# Altium schematic sheet Sheet2.SchDoc(Sheet2)
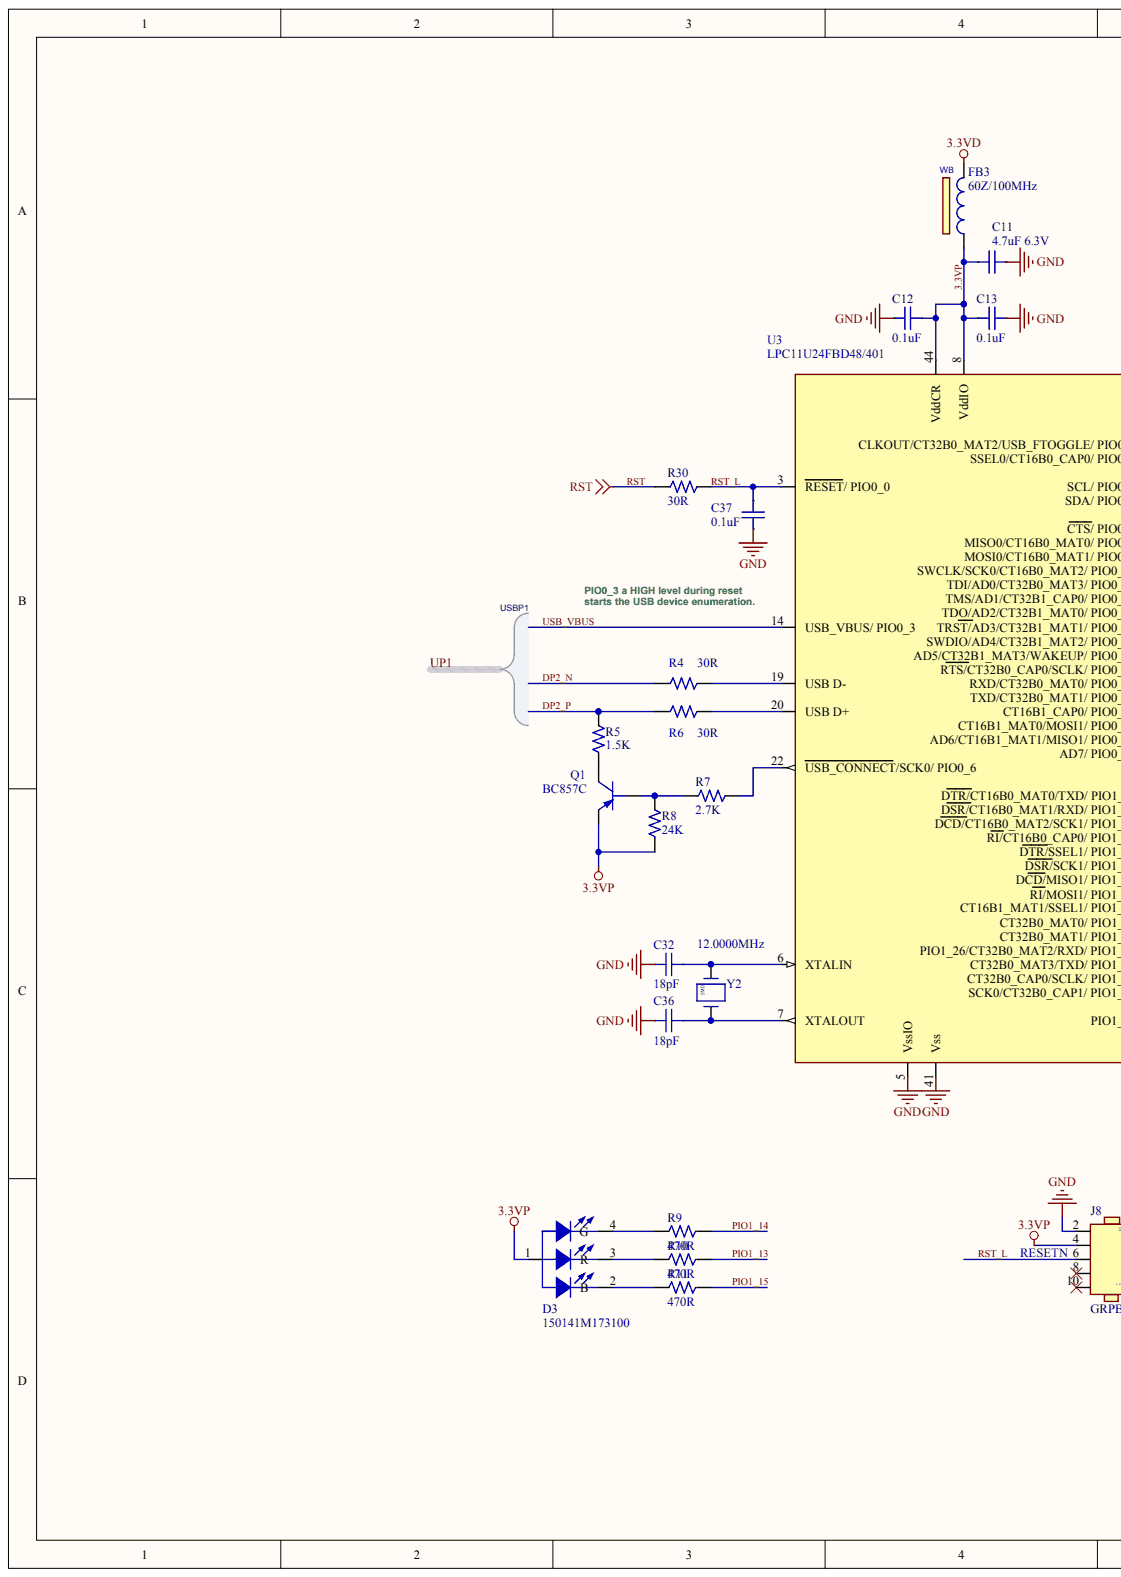
[diagram p0: Sheet2.SchDoc, left]
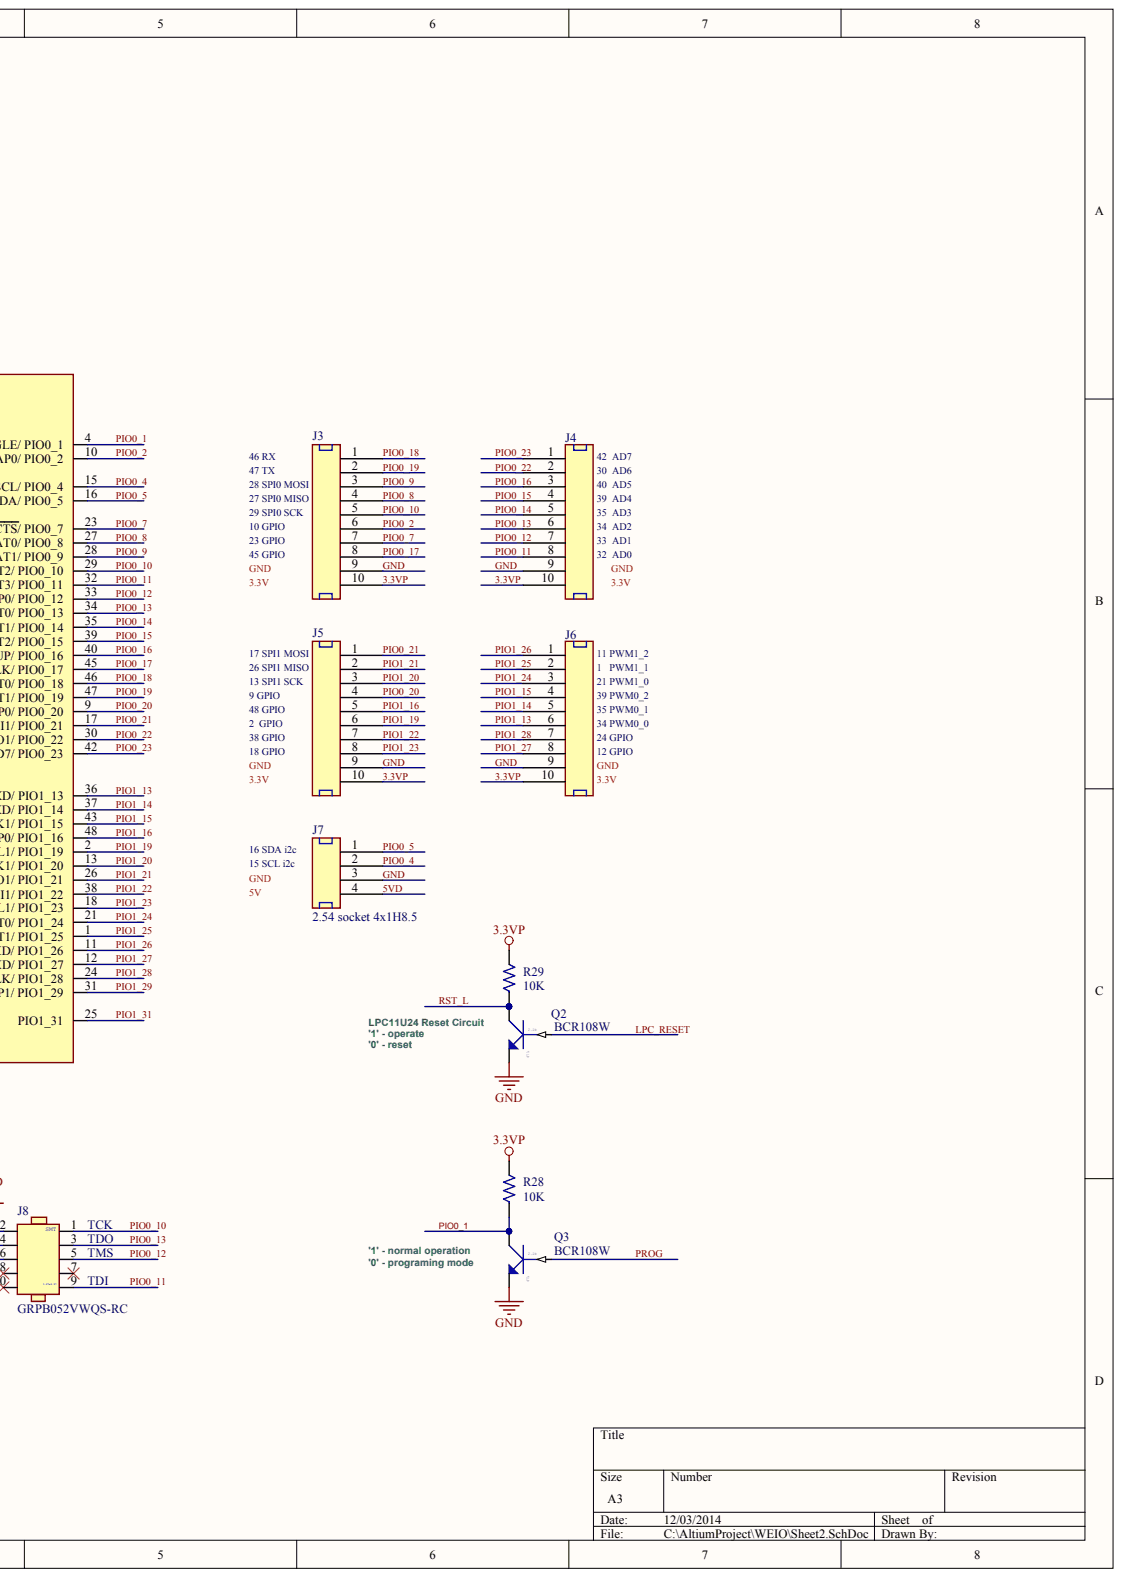
[diagram p1: Sheet2.SchDoc, right]

## Parts
C11  Voltage: 6.3V  (hidden comment: 4.7uF 6.3V)
C12  value 0.1uF  (hidden comment: 100nF)
C13  value 0.1uF  (hidden comment: 100nF)
C32  18pF
C36  18pF
C37  value 0.1uF  (hidden comment: 100nF)
D3  150141M173100
FB3  60Z/100MHz
J3  2.54 socket 10x1H8.5  (value not drawn)
J4  2.54 socket 10x1H8.5  (value not drawn)
J5  2.54 socket 10x1H8.5  (value not drawn)
J6  2.54 socket 10x1H8.5  (value not drawn)
J7  2.54 socket 4x1H8.5
J8  GRPB052VWQS-RC
Q1  BC857C
Q2  BCR108W
Q3  BCR108W
R4  30R
R5  1.5K
R6  30R
R7  2.7K
R8  24K
R9  470R
R10  470R
R11  470R
R28  10K
R29  10K
R30  30R
U3  LPC11U24FBD48/401
Y2  12.0000MHz

## Nets
3.3VD: FB3.2
3.3VP: C11.2 C12.2 C13.1 D3.1 FB3.1 J3.10 J4.10 J5.10 J6.10 J8.4 Q1.2 R8.1 R28.2 R29.2 U3.8(VddIO) U3.44(VddCR)
5VD: J7.4
DP2_N: R4.1
DP2_P: R5.2 R6.1
GND: C11.1 C12.1 C13.2 C32.2 C36.1 C37.2 J3.9 J4.9 J5.9 J6.9 J7.3 J8.2 Q2.2 Q3.2 U3.5(VssIO) U3.41(Vss)
LPC_RESET: Q2.1
PIO0_1: Q3.3 R28.1 U3.4
PIO0_2: J3.6 U3.10
PIO0_4: J7.2 U3.15
PIO0_5: J7.1 U3.16
PIO0_7: J3.7 U3.23
PIO0_8: J3.4 U3.27
PIO0_9: J3.3 U3.28
PIO0_10: J3.5 J8.1(SMT) U3.29
PIO0_11: J4.8 J8.9 U3.32
PIO0_12: J4.7 J8.5 U3.33
PIO0_13: J4.6 J8.3 U3.34
PIO0_14: J4.5 U3.35
PIO0_15: J4.4 U3.39
PIO0_16: J4.3 U3.40
PIO0_17: J3.8 U3.45
PIO0_18: J3.1 U3.46
PIO0_19: J3.2 U3.47
PIO0_20: J5.4 U3.9
PIO0_21: J5.1 U3.17
PIO0_22: J4.2 U3.30
PIO0_23: J4.1 U3.42
PIO1_13: J6.6 R10.1 U3.36(DTR/CT16B0_MAT0/TXD/_PIO1_13)
PIO1_14: J6.5 R9.1 U3.37(DSR/CT16B0_MAT1/RXD/_PIO1_14)
PIO1_15: J6.4 R11.1 U3.43(DCD/CT16B0_MAT2/SCK1/_PIO1_15)
PIO1_16: J5.5 U3.48(RI/CT16B0_CAP0/_PIO1_16)
PIO1_19: J5.6 U3.2(DTR/SSEL1/_PIO1_19)
PIO1_20: J5.3 U3.13(DSR/SCK1/_PIO1_20)
PIO1_21: J5.2 U3.26(DCD/MISO1/_PIO1_21)
PIO1_22: J5.7 U3.38(RI/MOSI1/_PIO1_22)
PIO1_23: J5.8 U3.18(CT16B1_MAT1/SSEL1/_PIO1_23)
PIO1_24: J6.3 U3.21(CT32B0_MAT0/_PIO1_24)
PIO1_25: J6.2 U3.1(CT32B0_MAT1/_PIO1_25)
PIO1_26: J6.1 U3.11(PIO1_26/CT32B0_MAT2/RXD/_PIO1_26)
PIO1_27: J6.8 U3.12(CT32B0_MAT3/TXD/_PIO1_27)
PIO1_28: J6.7 U3.24(CT32B0_CAP0/SCLK/_PIO1_28)
PIO1_29: U3.31(SCK0/CT32B0_CAP1/_PIO1_29)
PIO1_31: U3.25(PIO1_31)
PROG: Q3.1
RST: R30.1
RST_L: C37.1 J8.6 Q2.3 R29.1 R30.2 U3.3(RESET/)
USB_VBUS: U3.14(USB_VBUS/)
NetC32_1: C32.1 U3.6(XTALIN) Y2.1
NetC36_2: C36.2 U3.7(XTALOUT) Y2.3
NetD3_2: D3.2(B) R11.2
NetD3_3: D3.3(R) R10.2
NetD3_4: D3.4(G) R9.2
NetQ1_1: Q1.1 R7.1 R8.2
NetQ1_3: Q1.3 R5.1
NetR4_2: R4.2 U3.19(USB_D-)
NetR6_2: R6.2 U3.20(USB_D+)
NetR7_2: R7.2 U3.22(USB_CONNECT/SCK0/)

Not connected: J8 7 8 10

## Library data of the parts
C11 = (no symbol name): Part Number: 00000560; Manufacturer Part Number: C1608X5R0J475K; Manufacturer: TDK; Tolerance: +-10%; PSpice: C; Value: 4.7uF 6.3V; Part Type: Ceramic\1608; RoHS: Yes; Package: 180mmT&R4000; Availability: In Stock; Datasheet: E:\pdf\KONDENSATORIAI\CER_smd\e412_c.pdf
C12 C13 C37 = (no symbol name): Part Number: 00005500; Manufacturer Part Number: C1005X5R1C104K; Manufacturer: TDK; Voltage: 16V; Tolerance: +-10%; PSpice: C; Part Type: Ceramic\1005; RoHS: Yes; Availability: In Stock; Datasheet: E:\pdf\KONDENSATORIAI\CER_smd\e412_c.pdf
C32 C36 = (no symbol name): Part Number: 00000158; COMPHEIGHT: 0.8; Allegro PCB Footprint: C1608_I; Voltage: 50V; Tolerance: +-5%; PSpice: C; SMD: Yes; Distributor Part Number: 1001201; Distributor: Elgerta; Price: 0.015; Part Type: Ceramic; Availability: In Stock; Value: 18pF
FB3 = (no symbol name): Manufacturer: Coilmaster Electronics Co,,Ltd.; Manufacturer Part Number: MI1608-600; Part Number: 20000001; COMPHEIGHT: 0.8; Allegro PCB Footprint: MI1608_I; Tolerance: 25%; PSpice: L; Lead time: 3-4w; Price: 0.03; SMD: Yes; Part Type: Inductor\Ferrite beads 1608; Availability: 3-4weeks; Main distributor: Coilmaster; MOQ: 4000; ActivepartsID: http://www.coilmaster.com.tw/Web%20Site%20Catalogue%202007/T-MB,MI/MI1608-LF-RoHS.pdf; Data: 2009-05-15; Value: 60Z/100MHz; Datasheet: E:\pdf\induktyvumai\smd\MI1608-600-3A-LF-Teltonika.pdf
J8 = (no symbol name): Part Number: 70200208W; Manufacturer: Sullins Connector Solutions; COMPHEIGHT: 5; Rating: 250V; Allegro PCB Footprint: 127X127_12MH_SMT_H5; Gaminys: 8DEV; Komentaras: $; Part Type: Connector\1.27x1.27; SMD: Yes; Manufacturer Part Number: GRPB052VWQS-RC; Distributor Part Number: S9012E-05-ND; Distributor: Digi-Key; Price: 0.5075; Package: tube; ActivepartsID: http://media.digikey.com/pdf/Data%20Sheets/Sullins%20PDFs/GRPB_%20_2VWQS-RC%2010958-C.pdf; Data: 2013-06-07; Datasheet: E:\PDF\Jungtys\GRPB_ _2VWQS-RC 10958-C.pdf
Q1 = (no symbol name): Manufacturer Part Number: BC857C T/R; Manufacturer: NXP; Part Number: 40010110; COMPHEIGHT: 1.0; Allegro PCB Footprint: SOT23; Rating: 250mW; SMD: Yes; Distributor Part Number: 771-BC857C-T/R; Distributor: Mouser; Price: 0.043; Part Type: Transistors\PNP; Package: 3000T&R; Availability: In Stock; ActivepartsID: http://www.nxp.com/acrobat_download/datasheets/BC856_BC857_BC858_6.pdf; Data: 2008-04-23; Datasheet: E:\pdf\tranzistoriai\BC856_BC857_BC858_6.pdf
Q2 Q3 = (no symbol name): Part Number: 40030012; Manufacturer: INFINEON; COMPHEIGHT: 0.9; Rating: 250mW(<+124deg); Allegro PCB Footprint: SOT323_T,SOT323_TA; Part Type: Transistors; SMD: Yes; Manufacturer Part Number: BCR108W; Availability: In Stock; Data: 2008-11-27; Datasheet: E:\pdf\tranzistoriai\BCR108.PDF
R4 R6 R30 = (no symbol name): Part Number: 10011021W; Manufacturer Part Number: RC0402JR-0730RL; Manufacturer: YAGEO; COMPHEIGHT: 0.35; Power: 1/16W; Tolerance: 5%; Allegro PCB Footprint: R1005_TM2; PSpice: R; Gaminys: EAR2; Komentaras: $; Value: 30R; Part type: SMD\0402; SMD: Yes; Distributor Part Number: 311-30JRTR-ND; Distributor: Digi-Key; Price: 0.00213; Package: 10000T&R; Availability: In Stock; ActivepartsID: http://www.yageo.com/pdf/Pu-RC0402_51_PbFree_L_2.pdf; Data: 17/02/2010; Datasheet: E:\pdf\rezistoriai\Pu-RC0402_51_PbFree_L_2.pdf
R5 = (no symbol name): Part Number: 10011084; Manufacturer Part Number: RC0402JR-1K5L; Manufacturer: YAGEO; Power: 1/16W; Tolerance: 5%; PSpice: R; Value: 1.5K; Part type: SMD\0402; RoHS: Yes; Availability: In Stock; Datasheet: E:\pdf\rezistoriai\PSG_R-chip_Yageo_2005.pdf
R7 = (no symbol name): Part Number: 10011090; Manufacturer Part Number: RC0402JR-072K2L; Manufacturer: YAGEO; Power: 1/16W; Tolerance: 5%; PSpice: R; Value: 2.7K; Part type: SMD\0402; RoHS: Yes; Distributor Part Number: 311-2.2KJRTR-ND; Distributor: Digi-Key; Price: 0.011; Package: 10000T&R; Availability: In Stock; Data: 28/11/2007; Datasheet: E:\pdf\rezistoriai\PSG_R-chip_Yageo_2005.pdf
R8 = (no symbol name): Part Number: 10000180; Manufacturer Part Number: RC0603JR-0724K; Manufacturer: YAGEO; Power: 1/10W; Tolerance: 5%; PSpice: R; Value: 24K; Part type: Carbon Film; RoHS: Yes; Distributor Part Number: 1008322; Distributor: Elgerta; Price: 0.00367; Availability: In Stock
R9-R11 = (no symbol name): Part Number: 10011060; Manufacturer Part Number: RC0402JR-07470RL; Manufacturer: YAGEO; COMPHEIGHT: 0.35; Power: 1/16W; Tolerance: 5%; Allegro PCB Footprint: R1005_TM2; PSpice: R; Value: 470R; Part type: SMD\0402; SMD: Yes; Distributor Part Number: 311-470JRTR-ND; Distributor: Digi-Key; Price: 0.012; Package: 10000T&R; Availability: In Stock; ActivepartsID: http://www.yageo.com/pdf/PYu-RC0402_51_RoHS_L_3.pdf; Data: 18/09/2008; Datasheet: E:\pdf\rezistoriai\PYu-RC0402_51_RoHS_L_3.pdf
R28 R29 = (no symbol name): Part Number: 10011104; Manufacturer Part Number: RC0402JR-10KL; Manufacturer: YAGEO; COMPHEIGHT: 0.35; Power: 1/16W; Tolerance: 5%; Allegro PCB Footprint: R1005_TM2,R0402; PSpice: R; Komentaras: $; Value: 10K; Part type: SMD\0402; SMD: Yes; Distributor Part Number: 311-10KJRTR-ND; Distributor: Digi-Key; Price: 0.00213; Package: 10000T&R; Availability: In Stock; ActivepartsID: http://www.yageo.com/pdf/Pu-RC0402_51_PbFree_L_2.pdf; Data: 27/08/2010; Datasheet: E:\pdf\rezistoriai\PSG_R-chip_Yageo_2005.pdf
U3 = (no symbol name): Manufacturer: NXP Semiconductors; Part Number: 50100023W; COMPHEIGHT: 1.6; Allegro PCB Footprint: LQFP48_05_7X7; Komentaras: $; SMD: Yes; Manufacturer Part Number: LPC11U24FBD48/401; Distributor Part Number: 568-8460-ND; Distributor: Digi-Key; Price: 1.96; ComponentLink1URL: http://www.nxp.com/documents/data_sheet/LPC11U2X.pdf; Part Type: Microprocessor; Package: 250tray; Availability: 568-8460-ND; Data: 2013-06-04; ComponentLink2URL: E:\pdf\mikroschemos\mikrokontroleriai\LPC11U2X.pdf
Y2 = (no symbol name): Manufacturer Part Number: TXC - 7M-12.000MAAJ-T; Allegro PCB Footprint: abls_hc49_4h465x115; COMPHEIGHT: 4.2; Komentaras: $; Price: 0.21; Distributor: Digi-Key; Distributor Part Number: 535-9067-2-ND; SMD: Yes; Part Type: Quartz crystal\SMD; Package: 1000t&r; Availability: In Stock; ActivepartsID: http://www.abracon.com/Resonators/abls.pdf; Data: 2011-02-21; Value: 12.0000MHz; Datasheet: E:\pdf\osciliatoriai\ABLS.pdf
D3 J3-J7 = (no symbol name)
  D3: Part Number: 150141M173100; Value: 150141M173100
  J3-J6: Part Type: Connector\2.54 socket; RoHS: Yes; Distributor Part Number: BG302-10-A-L-G; Distributor: FARNEL; Value: 2.54 socket 10x1H8.5; Datasheet: E:\pdf\JUNGTYS\serie185[1].pdf
  J7: Value: 2.54 socket 4x1H8.5; Part Type: Connector\2.54 socket; RoHS: Yes; Distributor Part Number: 2084274; Distributor: FARNEL; Datasheet: E:\pdf\JUNGTYS\
